# Altium schematic sheet External_Connectors.SchDoc(External_Connectors)
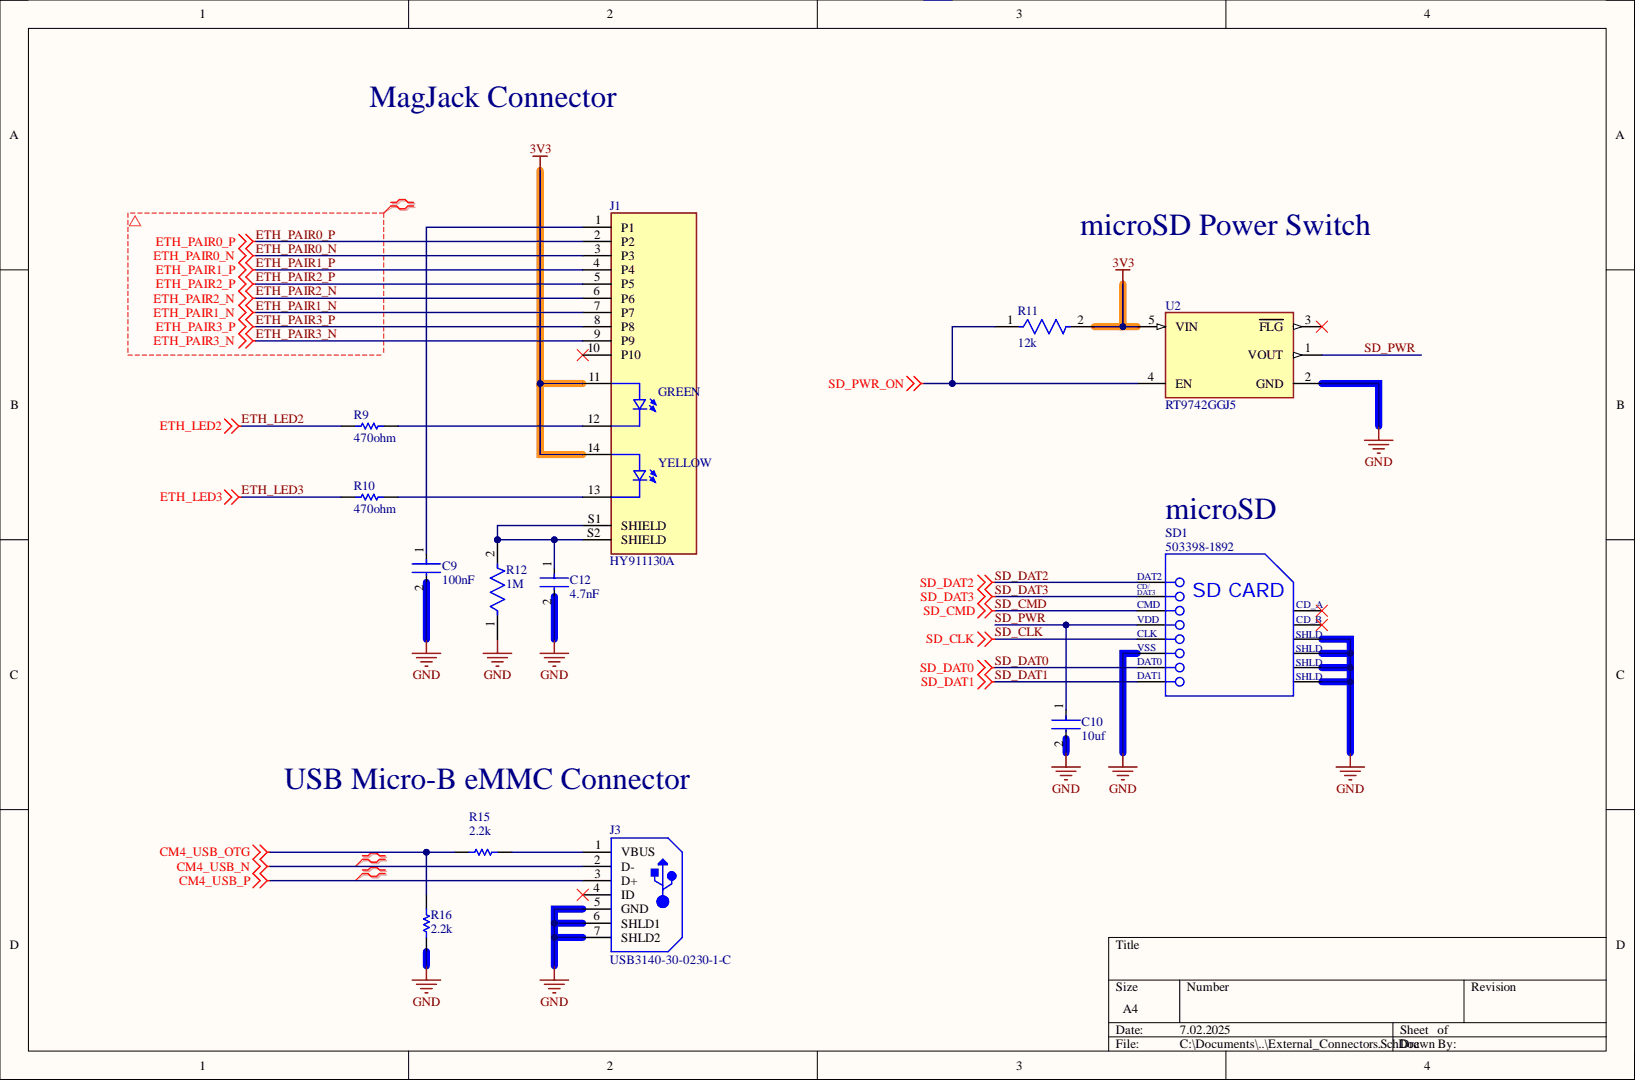
[diagram p0: External_Connectors.SchDoc]

## Parts
C9  100nF  [FP-C0402-BB-MFG]
C10  10uf  [FP-GRM188-0_2-IPC_C]
C12  4.7nF  [FP-0805-L_2_01_0_2-W_1_25-IPC_B]
J1  HY911130A  [HANRUN_HR911130A]
J3  USB3140-30-0230-1-C  [GCT-USB3140_V]
R9  470ohm  [RESC1005X40X25ML05T10]
R10  470ohm  [RESC1005X40X25ML05T10]
R11  12k  [FP-RC0402-0_4-IPC_C]
R12  1M  [FP-ERJ6EN-IPC_A]
R15  2.2k  [RESC1005X40X25LL05T05]
R16  2.2k  [RESC1005X40X25LL05T05]
SD1  503398-1892  [MOLX-503398-1892_V]
U2  RT9742GGJ5  [FP-RT9742GGJ5-IPC_C]

## Nets
3V3: J1.11 J1.14 R11.2 U2.5(VIN)
CM4_USB_N: J3.2(D-)
CM4_USB_OTG: R15.1 R16.2
CM4_USB_P: J3.3(D+)
GND: C9.2 C10.2 C12.2 J3.5(GND) J3.6(SHLD1) J3.7(SHLD2) R12.1 R16.1 SD1.6 SD1.11 SD1.12 SD1.13 SD1.14 U2.2(GND)
SD_PWR_ON: R11.1 U2.4(EN)
ETH_LED2: R9.1
ETH_LED3: R10.1
ETH_PAIR0_N: J1.3(P3)
ETH_PAIR0_P: J1.2(P2)
ETH_PAIR1_N: J1.7(P7)
ETH_PAIR1_P: J1.4(P4)
ETH_PAIR2_N: J1.6(P6)
ETH_PAIR2_P: J1.5(P5)
ETH_PAIR3_N: J1.9(P9)
ETH_PAIR3_P: J1.8(P8)
SD_CLK: SD1.5
SD_CMD: SD1.3
SD_DAT0: SD1.7
SD_DAT1: SD1.8
SD_DAT2: SD1.1
SD_DAT3: SD1.2(SD_CARD)
SD_PWR: C10.1 SD1.4 U2.1(VOUT)
NetC9_1: C9.1 J1.1(P1)
NetC12_1: C12.1 J1.S1(SHIELD) J1.S2(SHIELD) R12.2
NetJ1_12: J1.12 R9.2
NetJ1_13: J1.13 R10.2
NetJ3_1: J3.1(VBUS) R15.2

Not connected: J1 10(P10); J3 4(ID); SD1 9 10; U2 3(FLG)

## Library data of the parts
C9 = CMP-2008-02046-2: CAP CER 0.1UF 10V X5R 0402; Manufacturer: Kemet; Manufacturer Part Number: C0402C104K8PACTU; Capacitance: 0.10 μF; Material: Ceramic; Temperature Coefficient: 15%; Tolerance: 0.1; Value: 0.10 μF; Automotive: No; Category: Cap; Component Kind: Standard; ComponentLink2Description: Datasheet; ComponentLink2URL: https://content.kemet.com/datasheets/KEM_C1002_X7R_SMD.pdf; Device Class L1: Passive Components; Device Class L2: Capacitors; Device Class L3: Ceramic Capacitors; Digikey Description: CAP CER 0.1UF 10V X5R 0402; Height: 0.55mm; Ipc Land Pattern Name: CAPC10050X50; Lead Free: Yes; Library Name: Altium Content Vault; Mouser Description: Capacitor 100 nF 10 VDC 0402; Octopart: https://octopart.com/c0402c104k8pactu-kemet-140039; Package: C0402-BB; RoHS: TRUE; Temperature: -55°C to +125°C; Temperature Characteristic: X5R; Temperature Range High: +125°C; Temperature Range Low: -55°C; Voltage Rating: 10 V
C10 = CMP-06035-045466-1: Chip Multilayer Ceramic Capacitors for General Purpose, 0603, 10uF, X5R, 15%, 20%, 35V; Capacitance: 10uF; Case Code (Imperial): 0603; Case Code (Metric): 1608; Case/Package: 0603; Component Kind: Standard; Dielectric: X5R; Length: 0.063inch; Library Name: Altium Content Vault; Max Operating Temperature: 85°C; Min Operating Temperature: -55°C; Mount: Surface Mount; Packaging: Tape and Reel; Thickness: 0.039inch; Tolerance: 20%; Voltage Rating: 35V; Voltage Rating (DC): 35V; Width: 0.031inch
C12 = CMP-1036-03594-3: General Purpose Ceramic Capacitor, 0805, 4.7nF, 10%, X7R, 0.15, 50V; Dissipation Factor: 2.5%; Insulation Resistance: 100GR; Capacitance: 4.7nF; Case Code (Imperial): 0805; Case Code (Metric): 2012; Case/Package: 0805; Component Kind: Standard; Construction: Flat; Contact Plating: Tin; Depth: 1.25mm; Dielectric: X7R; Dielectric Material: Ceramic; Height: 0.94mm; Lead Free: Lead Free; Length: 2.01mm; Library Name: Altium Content Vault; Max Operating Temperature: 125°C; Min Operating Temperature: -55°C; Mount: Surface Mount; Number of Pins: 2; Package Quantity: 1; Packaging: Tape and Reel; Radiation Hardening: No; REACH SVHC: No SVHC; RoHS Compliant: Yes; Series: -; Size: 0.94mm; Termination: SMD/SMT; Thickness: 0.037inch; Tolerance: 10%; Voltage: 50V; Voltage Rating: 50V; Voltage Rating (DC): 50V; Width: 1.25mm
J1 = HR911130A: MF: hanrun; Availability: Not in stock; Check_prices: https://www.snapeda.com/parts/HR911130A/HanRun/view-part/?ref=eda; Component Kind: Standard; Library Name: HR911130A.IntLib; MP: HY911130A; Package: None; Price: None; SnapEDA_Link: https://www.snapeda.com/parts/HR911130A/HanRun/view-part/?ref=snap
J3 = CMP-2000-07368-1: Micro USB Receptacle, Type B, SMT, Vertical, with Through-Hole Shell Stakes, -30 to 85 degC, 5-Pin SMD, RoHS, Tape and Reel; Manufacturer: Global Connector Technology; Connector Mounting: PCB; Connector Type: USB2.0MicroB; Contact Termination: SurfaceMountVertical; No. of Contacts: 5; No. of Rows: 1; Pitch: 0.65mm; SVHC: NoSVHC(19-Dec-2012); Component Kind: Standard; Contact Material: Copper; Contact Plating: Gold; Datasheet URL: http://www.farnell.com/datasheets/1913332.pdf; Datasheet Version: Rev. B2, 04/2015; Gender: Receptacle; Library Name: Altium Content Vault; Manufacturer URL: http://gct.co/; Mounting Technology: SurfaceMount; No. of Positions: 5; Orientation: Vertical; Package Description: 5-Pin Surface Mount Device, Body 7.8 x 4 mm, Pitch 0.65 mm; Package Reference: USB3140; PartNumber: USB3140; Series: USB3140
R9 R10 = CMP-2002-07697-1: Manufacturer: Yageo / Phycomp; Part Number: RC0402FR-07470RL; Component Kind: Standard; Library Name: Altium Content Vault
R11 = CMP-2002-07378-2: RES SMD 12K OHM 1% 1/16W 0402; Max Power Dissipation: 63mW; Power Rating: 62.5mW; Resistance: 12kR; Temperature Coefficient: 100ppm/°C; Voltage Rating: 50V; Case Code (Imperial): 0402; Case Code (Metric): 1005; Case/Package: 0402; Component Kind: Standard; Construction: Rectangular; Depth: 0.5mm; Features: Moisture Resistant; Height: 0.016inch; Lead Free: Lead Free; Length: 1mm; Library Name: Altium Content Vault; Max Operating Temperature: 155°C; Military Standard: Not; Min Operating Temperature: -55°C; Number of Terminations: 2; Package Quantity: 1; Packaging: Tape and Reel; REACH SVHC: No SVHC; Resistance Tolerance: 1%; Resistor Type: Thick Film; RoHS Compliant: Yes; Series: RC; Size Code: 0402; Termination: SMD/SMT; Tolerance: 1%; Weight: 2.3E-05oz; Width: 0.02inch
R12 = CMP-2001-04378-6: RES SMD 1M OHM 1% 1/8W 0805; Max Power Dissipation: 125mW; Resistance: 1MR; Temperature Coefficient: 100ppm/°C; Voltage Rating: 150V; Case Code (Imperial): 0805; Case Code (Metric): 2012; Case/Package: 0805; Component Kind: Standard; Construction: Rectangular; Contact Plating: Tin; Depth: 1.25mm; Features: Automotive AEC-Q200; Height: 0.6mm; Lead Free: Lead Free; Length: 2mm; Library Name: Altium Content Vault; Max Operating Temperature: 155°C; Military Standard: Not; Min Operating Temperature: -55°C; Mount: Surface Mount; Number of Pins: 2; Number of Terminations: 2; Package Quantity: 1; Packaging: Tape and Reel; Power Rating: 125mW; Radiation Hardening: No; REACH SVHC: No SVHC; Resistance Tolerance: 1%; Resistor Type: Thick Film; RoHS Compliant: Yes; Series: ERJ; Size Code: 0805; Technology: THICK FILM; Termination: SMD/SMT; Tolerance: 1%; Voltage Rating (DC): 150V; Width: 1.2mm
R15 R16 = CMP-2002-01132-2: Precision Thick Film Chip Resistor, 2.2 kOhm, 100 mW, 1%, -55 to 155 degC, 2-Pin SMD (0402), RoHS, Tape and Reel; Manufacturer: Panasonic; Rated Power: 0.1W; Resistance: 2.2kO; Temp Coefficient: ±100ppm/°C; Component Kind: Standard; Datasheet URL: https://datasheet.ciiva.com/11821/0900766b80f80784-11821273.pdf; Datasheet Version: 03/2011; Library Name: Altium Content Vault; Manufacturer URL: http://www.panasonic.com/; Mounting Technology: SurfaceMount; Package Description: 2-Pin Surface Mount Device, Body 1 x 0.5 mm; Package Reference: 0402; Size Code: 0402; Tolerance (%): ±1%
SD1 = CMP-2000-05621-1: Micro SD Connector, Pitch 1.1 mm, 8 Position, Height 1.4 mm, -25 to 85 degC, RoHS, Tape and Reel; Brand: Molex; Current Rating: 500mA; Voltage Rating: 10VAC/DC; Component Kind: Standard; Datasheet URL: http://www.molex.com/pdm_docs/sd/5033981892_sd.pdf; Datasheet Version: Rev. E, 04/2006; Factory Pack Quantity: 2400; Library Name: Altium Content Vault; Manufacturer: Molex; Manufacturer URL: http://www.molex.com/; Mounting Technology: SurfaceMount; Package Description: Micro SD Connector, Pitch 1.1 mm, 8 Position, Height 1.4 mm; Package Reference: 503398-1892; Packaging: Reel; Product Category: MemoryCardConnectors; RoHS: Details; Series: 503398
U2 = CMP-79883-000001-1: IC PWR SWTCH N-CHAN 1:1 TSOT23-5; Fault Protection: Over Temperature; Interface: On/Off; Max Output Current: 1A; Number of Outputs: 1; On-State Resistance: 70mR; Output Configuration: High Side; Case/Package: SOT-23-5; Component Kind: Standard; Library Name: Altium Content Vault; Max Operating Temperature: 85°C; Min Operating Temperature: -40°C; Number of Pins: 23; Packaging: Tape and Reel; REACH SVHC: No SVHC; RoHS Compliant: Yes
